# Altium schematic sheet u1_heater_control.SchDoc(u1_heater_control)
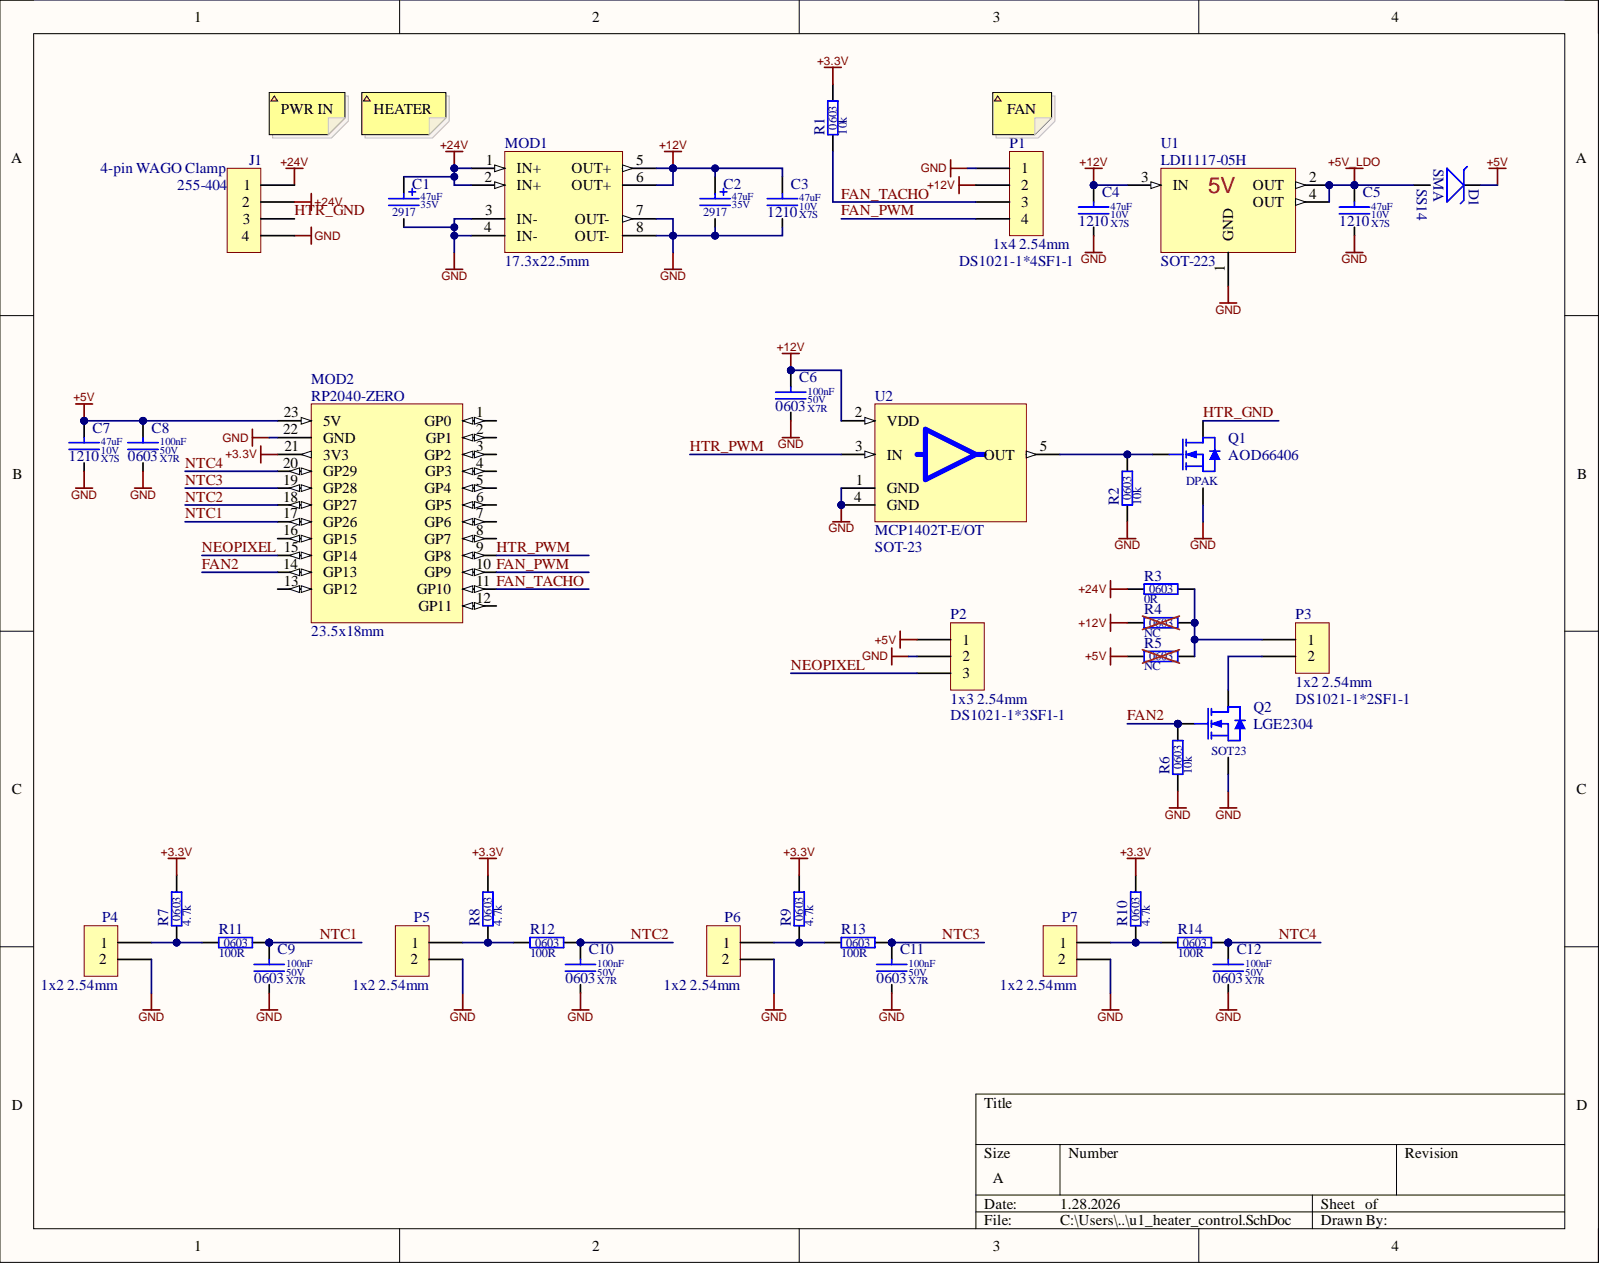
[diagram p0: u1_heater_control.SchDoc]

## Parts
C1  value 47uF  SCH_Footprint: 2917  Voltage: 35V  [CT_2917_7343]  (hidden comment: Tantalum Capacitor)
C2  value 47uF  SCH_Footprint: 2917  Voltage: 35V  [CT_2917_7343]  (hidden comment: Tantalum Capacitor)
C3  value 47uF  Dielectric: X7S  SCH_Footprint: 1210  Voltage: 10V  [C_1210_3225]  (hidden comment: Ceramic X7S)
C4  value 47uF  Dielectric: X7S  SCH_Footprint: 1210  Voltage: 10V  [C_1210_3225]  (hidden comment: Ceramic X7S)
C5  value 47uF  Dielectric: X7S  SCH_Footprint: 1210  Voltage: 10V  [C_1210_3225]  (hidden comment: Ceramic X7S)
C6  value 100nF  Dielectric: X7R  SCH_Footprint: 0603  Voltage: 50V  [C_0603_1608]  (hidden comment: Ceramic X7R)
C7  value 47uF  Dielectric: X7S  SCH_Footprint: 1210  Voltage: 10V  [C_1210_3225]  (hidden comment: Ceramic X7S)
C8  value 100nF  Dielectric: X7R  SCH_Footprint: 0603  Voltage: 50V  [C_0603_1608]  (hidden comment: Ceramic X7R)
C9  value 100nF  Dielectric: X7R  SCH_Footprint: 0603  Voltage: 50V  [C_0603_1608]  (hidden comment: Ceramic X7R)
C10  value 100nF  Dielectric: X7R  SCH_Footprint: 0603  Voltage: 50V  [C_0603_1608]  (hidden comment: Ceramic X7R)
C11  value 100nF  Dielectric: X7R  SCH_Footprint: 0603  Voltage: 50V  [C_0603_1608]  (hidden comment: Ceramic X7R)
C12  value 100nF  Dielectric: X7R  SCH_Footprint: 0603  Voltage: 50V  [C_0603_1608]  (hidden comment: Ceramic X7R)
D1  SS14  SCH_Footprint: SMA  [SMA_DO-214AC]
J1  value 255-404  Manufacturer Part Number: 255-404  [J_255-404]  (hidden comment: Wago Clamping terminal strip)
MOD1  SCH_Footprint: 17.3x22.5mm  [MOD_BUCK_MP1584]  (hidden comment: Low cost, Aliexpress-ready DC-DC buck converter using MP1584)
MOD2  value RP2040-ZERO  Manufacturer Part Number: RP2040-ZERO  SCH_Footprint: 23.5x18mm  [MOD_RP2040-ZERO]  (hidden comment: RP2040 module)
P1  value DS1021-1*4SF1-1  Manufacturer Part Number: DS1021-1*4SF1-1  SCH_Footprint: 1x4 2.54mm  [P_HEADER_1x4_2.54]  (hidden comment: Goldpin)
P2  value DS1021-1*3SF1-1  Manufacturer Part Number: DS1021-1*3SF1-1  SCH_Footprint: 1x3 2.54mm  [P_HEADER_1x3_2.54]  (hidden comment: Goldpin)
P3  value DS1021-1*2SF1-1  Manufacturer Part Number: DS1021-1*2SF1-1  SCH_Footprint: 1x2 2.54mm  [P_HEADER_1x2_2.54]  (hidden comment: Goldpin)
P4  SCH_Footprint: 1x2 2.54mm  [P_HEADER_1x2_2.54]  (hidden comment: Goldpin)
P5  SCH_Footprint: 1x2 2.54mm  [P_HEADER_1x2_2.54]  (hidden comment: Goldpin)
P6  SCH_Footprint: 1x2 2.54mm  [P_HEADER_1x2_2.54]  (hidden comment: Goldpin)
P7  SCH_Footprint: 1x2 2.54mm  [P_HEADER_1x2_2.54]  (hidden comment: Goldpin)
Q1  AOD66406  SCH_Footprint: DPAK  [DPAK_TO252-3_SC63_M]
Q2  LGE2304  SCH_Footprint: SOT23  [SOT23_TO236_SC59]
R1  value 10k  SCH_Footprint: 0603  [R_0603_1608]  (hidden comment: Resistor)
R2  value 10k  SCH_Footprint: 0603  [R_0603_1608]  (hidden comment: Resistor)
R3  value 0R  SCH_Footprint: 0603  [R_0603_1608]  (hidden comment: Resistor)
R4  value NC  SCH_Footprint: 0603  [R_NC_0603_1608]  (hidden comment: Resistor)  (no BOM)
R5  value NC  SCH_Footprint: 0603  [R_NC_0603_1608]  (hidden comment: Resistor)  (no BOM)
R6  value 10k  SCH_Footprint: 0603  [R_0603_1608]  (hidden comment: Resistor)
R7  value 4.7k  SCH_Footprint: 0603  [R_0603_1608]  (hidden comment: Resistor)
R8  value 4.7k  SCH_Footprint: 0603  [R_0603_1608]  (hidden comment: Resistor)
R9  value 4.7k  SCH_Footprint: 0603  [R_0603_1608]  (hidden comment: Resistor)
R10  value 4.7k  SCH_Footprint: 0603  [R_0603_1608]  (hidden comment: Resistor)
R11  value 100R  SCH_Footprint: 0603  [R_0603_1608]  (hidden comment: Resistor)
R12  value 100R  SCH_Footprint: 0603  [R_0603_1608]  (hidden comment: Resistor)
R13  value 100R  SCH_Footprint: 0603  [R_0603_1608]  (hidden comment: Resistor)
R14  value 100R  SCH_Footprint: 0603  [R_0603_1608]  (hidden comment: Resistor)
U1  value LDI1117-05H  Manufacturer Part Number: LDI1117-05H  SCH_Footprint: SOT-223  Voltage: 5V  [SOT223_SC73]  (hidden comment: 1-A, 20-V, linear voltage regulator 4-SOT-223)
U2  value MCP1402T-E/OT  Manufacturer Part Number: MCP1402T-E/OT  SCH_Footprint: SOT-23  [SOT23-5_SC74A_SOT753_M]  (hidden comment: Mosfet driver)

## Nets
+3.3V: MOD2.21(3V3) R1.2 R7.2 R8.2 R9.2 R10.2
+5V: C7.1 C8.1 D1.1 MOD2.23(5V) P2.1 R5.1
+5V_LDO: C5.1 D1.2 U1.2(OUT) U1.4(OUT)
+12V: C2.1 C3.1 C4.1 C6.1 MOD1.5(OUT+) MOD1.6(OUT+) P1.2 R4.1 U1.3(IN) U2.2(VDD)
+24V: C1.1 J1.1 J1.2 MOD1.1(IN+) MOD1.2(IN+) R3.1
GND: C1.2 C2.2 C3.2 C4.2 C5.2 C6.2 C7.2 C8.2 C9.2 C10.2 C11.2 C12.2 J1.4 MOD1.3(IN-) MOD1.4(IN-) MOD1.7(OUT-) MOD1.8(OUT-) MOD2.22(GND) P1.1 P2.2 P4.2 P5.2 P6.2 P7.2 Q1.3 Q2.2 R2.1 R6.1 U1.1(GND) U2.1(GND) U2.4(GND)
FAN2: MOD2.14(GP13) Q2.1 R6.2
FAN_PWM: MOD2.10(GP9) P1.4
FAN_TACHO: MOD2.11(GP10) P1.3 R1.1
HTR_GND: J1.3 Q1.2
HTR_PWM: MOD2.9(GP8) U2.3(IN)
NEOPIXEL: MOD2.15(GP14) P2.3
NTC1: C9.1 MOD2.17(GP26) R11.2
NTC2: C10.1 MOD2.18(GP27) R12.2
NTC3: C11.1 MOD2.19(GP28) R13.2
NTC4: C12.1 MOD2.20(GP29) R14.2
NetP3_1: P3.1 R3.2 R4.2 R5.2
NetP3_2: P3.2 Q2.3
NetP4_1: P4.1 R7.1 R11.1
NetP5_1: P5.1 R8.1 R12.1
NetP6_1: P6.1 R9.1 R13.1
NetP7_1: P7.1 R10.1 R14.1
NetQ1_1: Q1.1 R2.2 U2.5(OUT)

Not connected: MOD2 1(GP0) 2(GP1) 3(GP2) 4(GP3) 5(GP4) 6(GP5) 7(GP6) 8(GP7) 12(GP11) 13(GP12) 16(GP15)

## Library data of the parts
C1 C2 = CT_47uF_35V_TA2O5_2917_20_T541: Capacitor; Manufacturer: Kemet; Manufacturer Part Number: T541X476M035AH6710; Database Table Name: Capacitors; Dielectric: TA2O5; Tolerance (%): 20; Component Kind: Standard; HelpURL: https://content.kemet.com/datasheets/KEM_T2077_T540-541.pdf; Library Name: Library.DBLib; Part Number: CT_47uF_35V_TA2O5_2917_20_T541; Supplier 1: TME; Supplier 2: RS Components; Supplier 3: Farnell; Supplier 4: Mouser; Supplier 5: Digi-Key; Supplier 6: LCSC; Supplier 7: Avnet; Supplier Part Number 3: 2885835; Supplier Part Number 4: 80-T541X476M35AH6710; Supplier Part Number 5: 399-T541X476M035AH6710CT-ND; Supplier Part Number 7: T541X476M035AH6710
C3-C5 C7 = C_47uF_10V_X7S_1210_10_AECQ1: Capacitor; Manufacturer: Murata; Manufacturer Part Number: GCM32EC71A476KE02; AEC-Q: 1; Database Table Name: Capacitors; Tolerance (%): 10; Component Kind: Standard; HelpURL: https://www.murata.com/products/productdetail?partno=GCM32EC71A476KE02%23; Library Name: Library.DBLib; Part Number: C_47uF_10V_X7S_1210_10_AECQ1; Supplier 1: TME; Supplier 2: RS Components; Supplier 3: Farnell; Supplier 4: Mouser; Supplier 5: Digi-Key; Supplier 6: LCSC; Supplier Part Number 1: GCM32EC71A476KE02L; Supplier Part Number 3: 4128612; Supplier Part Number 4: 81-GCM32EC71A476KE2L; Supplier Part Number 5: 490-GCM32EC71A476KE02LCT-ND; Supplier Part Number 6: C3019535
C6 C8-C12 = C_100nF_50V_X7R_0603_5: Capacitor; Manufacturer: Samsung; Manufacturer Part Number: CL10B104JB8NNNC; Database Table Name: Capacitors; Tolerance (%): 5; Component Kind: Standard; HelpURL: http://www.tme.eu/pl/Document/4a42202b32dab16128fe107dd69598cc/samsung-chip-cap.pdf; Library Name: Library.DBLib; Part Number: C_100nF_50V_X7R_0603_5; Supplier 1: TME; Supplier 2: RS Components; Supplier 3: Farnell; Supplier 4: Mouser; Supplier 5: Digi-Key; Supplier 6: LCSC; Supplier Part Number 1: CL10B104JB8NNNC; Supplier Part Number 2: 7665455; Supplier Part Number 5: 1276-1033-1-ND; Supplier Part Number 6: C24452
D1 = DS_SS14_1A_40V_SMA: Manufacturer: ON Semiconductor; Current: 1A; Database Table Name: Diodes; Voltage: 40V; Component Kind: Standard; HelpURL: https://www.vishay.com/docs/88746/ss12.pdf; Library Name: Library.DBLib; Manufacturer Part Number: SS14; Part Number: DS_SS14_1A_40V_SMA; Supplier 1: TME; Supplier 2: RS Components; Supplier 3: Farnell; Supplier 4: Mouser; Supplier 5: Digi-Key; Supplier 6: LCSC; Supplier Part Number 1: SS14-FAI; Supplier Part Number 2: 7390467P; Supplier Part Number 3: 1467537; Supplier Part Number 4: 512-SS14; Supplier Part Number 5: SS14CT-ND; Value: SS14
J1 = J_255-404: Pin Header; Database Table Name: Connectors; SCH_Footprint: 4-pin WAGO Clamp; Component Kind: Standard; HelpURL: https://www.tme.eu/Document/96bfd47690e21918702c38ee5593d4a8/255-404.pdf; Library Name: Library.DBLib; Manufacturer: Wago; Part Number: J_255-404; Supplier 1: TME; Supplier 2: RS Components; Supplier 3: Farnell; Supplier 4: Mouser; Supplier 5: Digi-Key; Supplier 6: LCSC; Supplier Part Number 1: 255-404
MOD1 = MOD_BUCK_MP1584: Database Table Name: Modules; Component Kind: Standard; HelpURL: https://pl.aliexpress.com/item/32341006065.html; Library Name: Library.DBLib; Manufacturer: -; Manufacturer Part Number: -; Part Number: MOD_BUCK_MP1584; Supplier 1: TME; Supplier 2: RS Components; Supplier 3: Farnell; Supplier 4: Mouser; Supplier 5: Digi-Key; Supplier 6: LCSC; Supplier 7: -; Supplier Part Number 7: -; Value: -
MOD2 = MOD_RP2040-ZERO: Manufacturer: Waveshare; Database Table Name: Modules; Component Kind: Standard; HelpURL: https://www.waveshare.com/wiki/RP2040-Zero; Library Name: Library.DBLib; Part Number: MOD_RP2040-ZERO; Supplier 1: TME; Supplier 2: RS Components; Supplier 3: Farnell; Supplier 4: Mouser; Supplier 5: Digi-Key; Supplier 6: LCSC; Supplier 7: Botland; Supplier Part Number 7: WSR-20536
P1 = P_HEADER_1x4_2.54: Pin Header; Manufacturer: Connfly; Database Table Name: Connectors; Component Kind: Standard; HelpURL: http://datasheet.octopart.com/DS1021-1%2A4SF1-1-Connfly-datasheet-65537859.pdf; Library Name: Library.DBLib; Part Number: P_HEADER_1x4_2.54; Supplier 1: TME; Supplier 2: RS Components; Supplier 3: Farnell; Supplier 4: Mouser; Supplier 5: Digi-Key; Supplier 6: LCSC; Supplier Part Number 1: ZL201-04G
P2 = P_HEADER_1x3_2.54: Pin Header; Manufacturer: Connfly; Database Table Name: Connectors; Component Kind: Standard; HelpURL: http://www.tme.eu/en/Document/cc301e58a5da78cb543a94b5a5b7f06a/DS1021.pdf; Library Name: Library.DBLib; Part Number: P_HEADER_1x3_2.54; Supplier 1: TME; Supplier 2: RS Components; Supplier 3: Farnell; Supplier 4: Mouser; Supplier 5: Digi-Key; Supplier 6: LCSC; Supplier Part Number 1: ZL201-03G
P3 = P_HEADER_1x2_2.54: Pin Header; Manufacturer: Connfly; Database Table Name: Connectors; Component Kind: Standard; HelpURL: http://www.tme.eu/en/Document/cc301e58a5da78cb543a94b5a5b7f06a/DS1021.pdf; Library Name: Library.DBLib; Part Number: P_HEADER_1x2_2.54; Supplier 1: TME; Supplier 2: RS Components; Supplier 3: Farnell; Supplier 4: Mouser; Supplier 5: Digi-Key; Supplier 6: LCSC; Supplier Part Number 1: ZL201-02G
P4-P7 = P_HEADER_1x2_2.54: Pin Header; Manufacturer: Connfly; Manufacturer Part Number: DS1021-1*2SF1-1; Database Table Name: Connectors; Value: DS1021-1*2SF1-1; Component Kind: Standard; HelpURL: http://www.tme.eu/en/Document/cc301e58a5da78cb543a94b5a5b7f06a/DS1021.pdf; Library Name: Library.DBLib; Part Number: P_HEADER_1x2_2.54; Supplier 1: TME; Supplier 2: RS Components; Supplier 3: Farnell; Supplier 4: Mouser; Supplier 5: Digi-Key; Supplier 6: LCSC; Supplier Part Number 1: ZL201-02G
Q1 = QN_AOD66406: Manufacturer: Alpha&Omega Semiconductor; Current: 60A; Database Table Name: Transistors; Type: N-MOS; Voltage: 40V; Component Kind: Standard; HelpURL: https://www.aosmd.com/sites/default/files/res/datasheets/AOD66406.pdf; Library Name: Library.DBLib; Manufacturer Part Number: AOD66406; Part Number: QN_AOD66406; Supplier 1: TME; Supplier 2: RS Components; Supplier 3: Farnell; Supplier 4: Mouser; Supplier 5: Digi-Key; Supplier 6: LCSC; Supplier Part Number 1: AOD66406; Supplier Part Number 5: 785-AOD66406CT-ND; Value: AOD66406
Q2 = QN_LGE2304: Manufacturer: Luguang Electronic Technology; Current: 3.3A; Database Table Name: Transistors; Type: N-MOS; Voltage: 30V; Component Kind: Standard; HelpURL: https://www.tme.eu/Document/1846c69c61200204c6c8d6a9d434d9dd/LGE2304.pdf; Library Name: Library.DBLib; Manufacturer Part Number: LGE2304; Part Number: QN_LGE2304; Supplier 1: TME; Supplier 2: RS Components; Supplier 3: Farnell; Supplier 4: Mouser; Supplier 5: Digi-Key; Supplier 6: LCSC; Supplier Part Number 1: LGE2304-LGE; Value: LGE2304
R1 R2 R6 = R_10k_0603_1_AECQ0: Manufacturer: Panasonic; Manufacturer Part Number: ERJ-3EKF1002V; AEC-Q: 0; Database Table Name: Resistors; Power: 100mW; Tolerance: 1%; Voltage: 75V; Component Kind: Standard; HelpURL: https://industrial.panasonic.com/cdbs/www-data/pdf/RDA0000/AOA0000C304.pdf; Library Name: Library.DBLib; Part Number: R_10k_0603_1_AECQ0; Supplier 1: TME; Supplier 2: RS Components; Supplier 3: Farnell; Supplier 4: Mouser; Supplier 5: Digi-Key; Supplier 6: LCSC; Supplier Part Number 1: ERJ3EKF1002V; Supplier Part Number 3: 2303192; Supplier Part Number 4: 667-ERJ-3EKF1002V; Supplier Part Number 5: P10.0KHCT-ND; Supplier Part Number 6: C191124
R3 = R_0R_0603_1: Manufacturer: Yageo; Manufacturer Part Number: RC0603FR-070RL; Database Table Name: Resistors; Power: 100mW; Tolerance: 1%; Voltage: 75V; Component Kind: Standard; HelpURL: https://datasheet.octopart.com/RC0603FR-070RL-Yageo-datasheet-8328172.pdf; Library Name: Library.DBLib; Part Number: R_0R_0603_1; Supplier 1: TME; Supplier 2: RS Components; Supplier 3: Farnell; Supplier 4: Mouser; Supplier 5: Digi-Key; Supplier 6: LCSC; Supplier Part Number 1: RC0603FR-070RL; Supplier Part Number 3: 2309106; Supplier Part Number 4: 603-RC0603FR-070RL; Supplier Part Number 5: 311-0.0HRCT-ND; Supplier Part Number 6: C100044
R4 R5 = R_GENERIC_0603: Database Table Name: Resistors; HelpURL: -; Library Name: Library.DBLib; Manufacturer: -; Manufacturer Part Number: -; Part Number: R_GENERIC_0603; Supplier 1: TME; Supplier 2: RS Components; Supplier 3: Farnell; Supplier 4: Mouser; Supplier 5: Digi-Key; Supplier 6: LCSC
R7-R10 = R_4.7k_0603_1: Manufacturer: Yageo; Manufacturer Part Number: RC0603FR-074K7L; Database Table Name: Resistors; Power: 100mW; Tolerance: 1%; Voltage: 75V; Component Kind: Standard; HelpURL: http://www.farnell.com/datasheets/2167052.pdf?_ga=2.156163131.1690599162.1548417389-1379097396.1542296996&_gac=1.18389067.1547230258.Cj0KCQiAmuHhBRD0ARIsAFWyPwhX8HcvXnM3tA0ZBodZLzDIjH2ZxLpjT5LWo9bad_HPRbU8LfPq44UaAhEwEALw_wcB; Library Name: Library.DBLib; Part Number: R_4.7k_0603_1; Supplier 1: TME; Supplier 2: RS Components; Supplier 3: Farnell; Supplier 4: Mouser; Supplier 5: Digi-Key; Supplier 6: LCSC; Supplier Part Number 1: RC0603FR-074K7; Supplier Part Number 3: 9238565; Supplier Part Number 4: 603-RC0603FR-074K7L; Supplier Part Number 5: 311-4.70KHRCT-ND
R11-R14 = R_100R_0603_1: Manufacturer: Yageo; Manufacturer Part Number: RC0603FR-07100RL; Database Table Name: Resistors; Power: 100mW; Tolerance: 1%; Voltage: 50V; Component Kind: Standard; HelpURL: http://www.tme.eu/en/Document/d2a72e545e5c8eb7bf2a04fa97535928/rc0603yageo.pdf; Library Name: Library.DBLib; Part Number: R_100R_0603_1; Supplier 1: TME; Supplier 2: RS Components; Supplier 3: Farnell; Supplier 4: Mouser; Supplier 5: Digi-Key; Supplier 6: LCSC; Supplier Part Number 1: RC0603FR-07100R; Supplier Part Number 3: 2131804; Supplier Part Number 4: 603-RC0603FR-07100RL; Supplier Part Number 5: 311-100HRCT-ND
U1 = VL_LDI1117-05H: typical configuration; Manufacturer: Diotec; Current: 1A; Database Table Name: Voltage Regulators; Component Kind: Standard; HelpURL: https://www.tme.eu/Document/084a5eb18462203f10c4803bc35208f3/ldi1117h.pdf; Library Name: Library.DBLib; Part Number: VL_LDI1117-05H; Supplier 1: TME; Supplier 2: RS Components; Supplier 3: Farnell; Supplier 4: Mouser; Supplier 5: Digi-Key; Supplier 6: LCSC; Supplier Part Number 1: LDI1117-05H-DIO; Supplier Part Number 3: 4553249; Supplier Part Number 4: 637-LDI1117-05H; Supplier Part Number 5: 4878-LDI1117-05HCT-ND
U2 = U_MCP1402T-E/OT: Manufacturer: Microchip; Database Table Name: IC Other; Component Kind: Standard; HelpURL: http://ww1.microchip.com/downloads/en/DeviceDoc/20002052D.pdf; Library Name: Library.DBLib; Part Number: U_MCP1402T-E/OT; Supplier 1: TME; Supplier 2: RS Components; Supplier 3: Farnell; Supplier 4: Mouser; Supplier 5: Digi-Key; Supplier 6: LCSC; Supplier Part Number 1: MCP1402T-E/OT; Supplier Part Number 2: 6684203; Supplier Part Number 3: 1439711; Supplier Part Number 4: 579-MCP1402T-E/OT; Supplier Part Number 5: MCP1402T-E/OTCT-ND
